# Altium schematic sheet [04] - INTERFACE BOARD MOMIU SCHEMATIC.SchDoc(Designator)
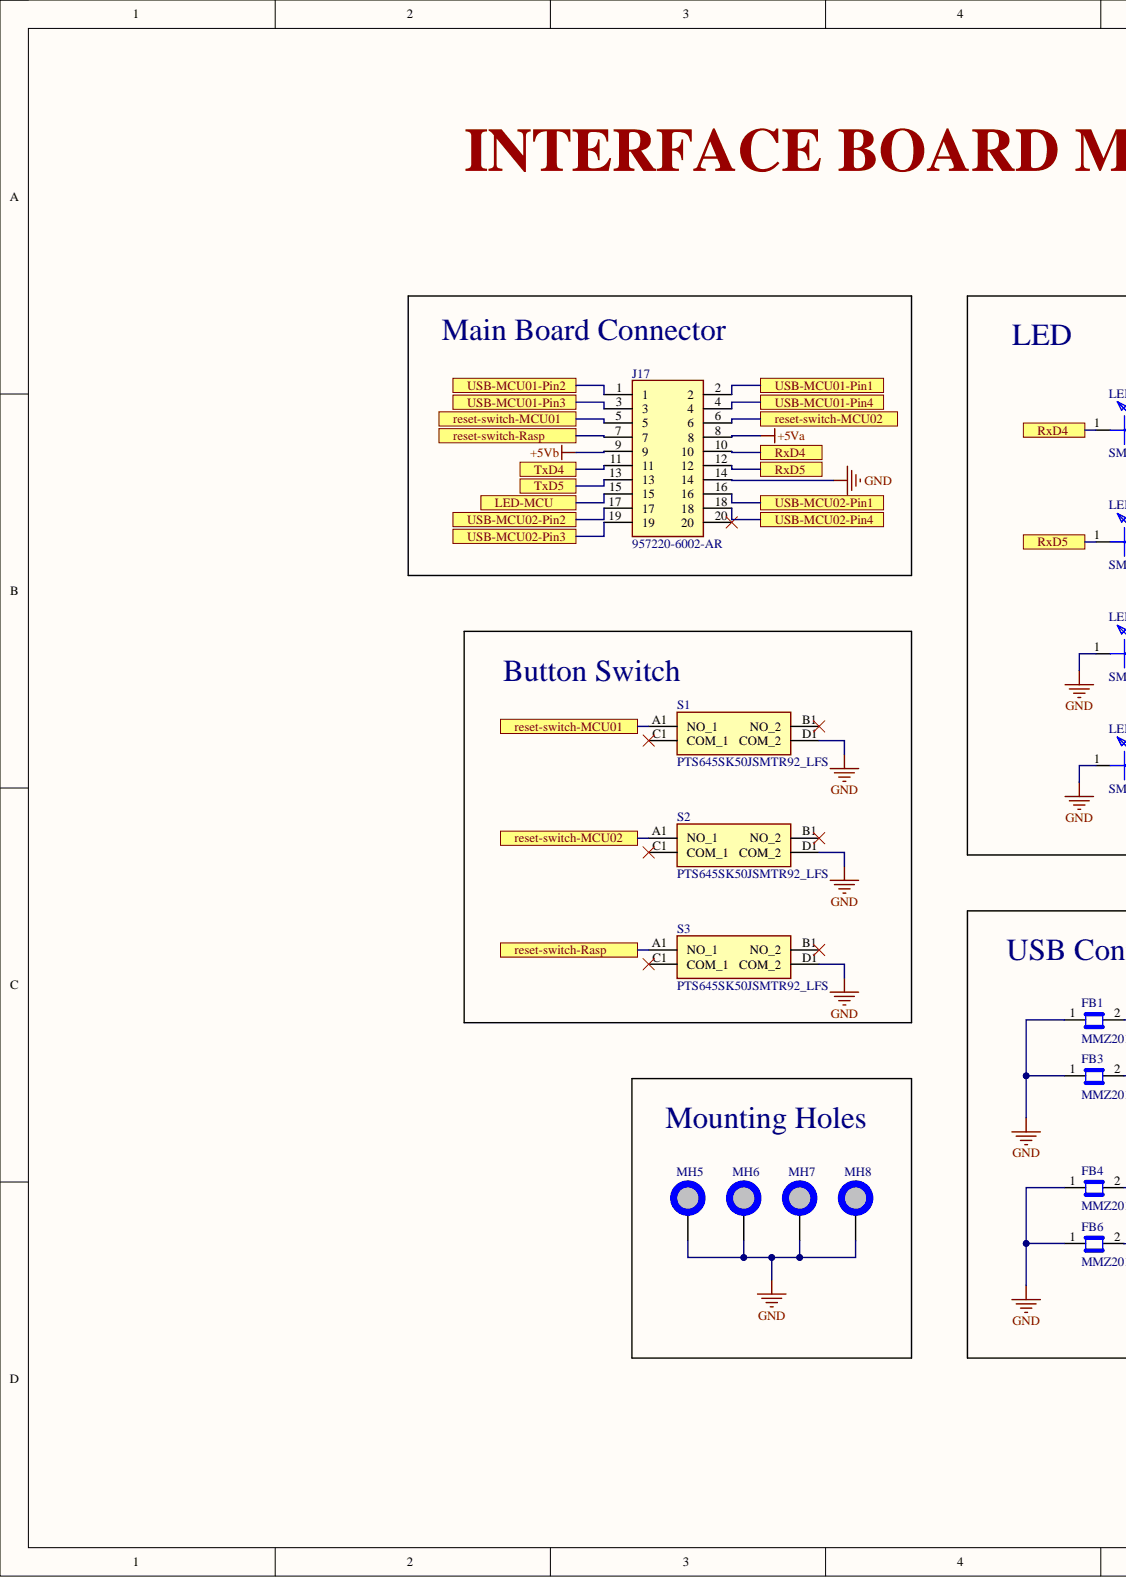
[diagram p0: [04] - INTERFACE BOARD MOMIU SCHEMATIC.SchDoc, left]
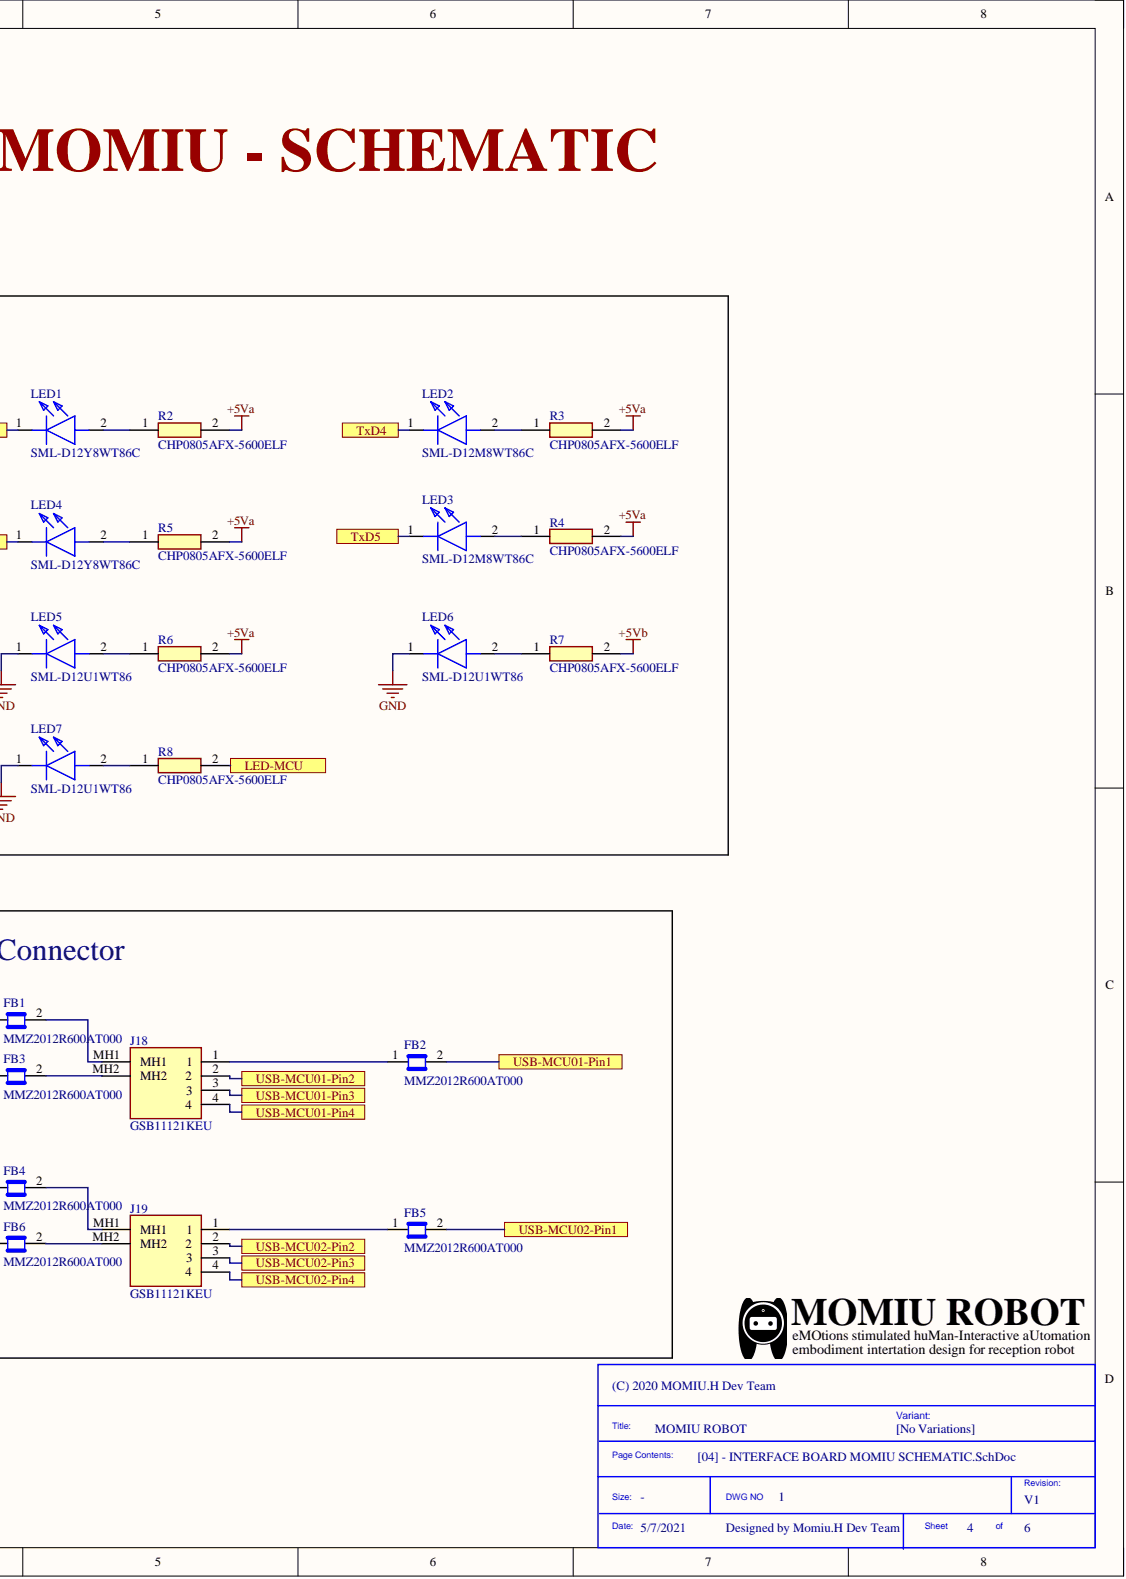
[diagram p1: [04] - INTERFACE BOARD MOMIU SCHEMATIC.SchDoc, right]

## Parts
FB1  MMZ2012R600AT000  [CAPC2012X100N]
FB2  MMZ2012R600AT000  [CAPC2012X100N]
FB3  MMZ2012R600AT000  [CAPC2012X100N]
FB4  MMZ2012R600AT000  [CAPC2012X100N]
FB5  MMZ2012R600AT000  [CAPC2012X100N]
FB6  MMZ2012R600AT000  [CAPC2012X100N]
J17  957220-6002-AR  [9572206002AR]
J18  GSB11121KEU  [GSB11121KEU]
J19  GSB11121KEU  [GSB11121KEU]
LED1  SML-D12Y8WT86C  [LEDC1608X65N]
LED2  SML-D12M8WT86C  [LEDC1608X65N]
LED3  SML-D12M8WT86C  [LEDC1608X65N]
LED4  SML-D12Y8WT86C  [LEDC1608X65N]
LED5  SML-D12U1WT86  [LEDC1608X65N]
LED6  SML-D12U1WT86  [LEDC1608X65N]
LED7  SML-D12U1WT86  [LEDC1608X65N]
MH5  MH_7.4 MMC_3.2 MMD  [MH_7.4 MMC_3.2 MMD]  (value not drawn)  (no BOM)
MH6  MH_7.4 MMC_3.2 MMD  [MH_7.4 MMC_3.2 MMD]  (value not drawn)  (no BOM)
MH7  MH_7.4 MMC_3.2 MMD  [MH_7.4 MMC_3.2 MMD]  (value not drawn)  (no BOM)
MH8  MH_7.4 MMC_3.2 MMD  [MH_7.4 MMC_3.2 MMD]  (value not drawn)  (no BOM)
R2  CHP0805AFX-5600ELF  [RESC2012X60N]
R3  CHP0805AFX-5600ELF  [RESC2012X60N]
R4  CHP0805AFX-5600ELF  [RESC2012X60N]
R5  CHP0805AFX-5600ELF  [RESC2012X60N]
R6  CHP0805AFX-5600ELF  [RESC2012X60N]
R7  CHP0805AFX-5600ELF  [RESC2012X60N]
R8  CHP0805AFX-5600ELF  [RESC2012X60N]
S1  PTS645SK50JSMTR92_LFS  [PTS645SK50JSMTR92LFS]
S2  PTS645SK50JSMTR92_LFS  [PTS645SK50JSMTR92LFS]
S3  PTS645SK50JSMTR92_LFS  [PTS645SK50JSMTR92LFS]

## Ports
LED-MCU, reset-switch-MCU01, reset-switch-MCU02, reset-switch-Rasp, RxD4, RxD5, TxD4, TxD5, USB-MCU01-Pin1, USB-MCU01-Pin2, USB-MCU01-Pin3, USB-MCU01-Pin4, USB-MCU02-Pin1, USB-MCU02-Pin2, USB-MCU02-Pin3, USB-MCU02-Pin4

## Nets
+5Va: J17.8 R2.2 R3.2 R4.2 R5.2 R6.2
+5Vb: J17.9 R7.2
GND: FB1.1 FB3.1 FB4.1 FB6.1 J17.14 LED5.1 LED6.1 LED7.1 MH5.1 MH6.1 MH7.1 MH8.1 S1.D1(COM_2) S2.D1(COM_2) S3.D1(COM_2)
LED-MCU: J17.15 R8.2 ; port LED-MCU
reset-switch-MCU01: J17.5 S1.A1(NO_1) ; port reset-switch-MCU01
reset-switch-MCU02: J17.6 S2.A1(NO_1) ; port reset-switch-MCU02
reset-switch-Rasp: J17.7 S3.A1(NO_1) ; port reset-switch-Rasp
RxD4: J17.10 LED1.1 ; port RxD4
RxD5: J17.12 LED4.1 ; port RxD5
TxD4: J17.11 LED2.1 ; port TxD4
TxD5: J17.13 LED3.1 ; port TxD5
USB-MCU01-Pin1: FB2.2 J17.2 ; port USB-MCU01-Pin1
USB-MCU01-Pin2: J17.1 J18.2 ; port USB-MCU01-Pin2
USB-MCU01-Pin3: J17.3 J18.3 ; port USB-MCU01-Pin3
USB-MCU01-Pin4: J17.4 J18.4 ; port USB-MCU01-Pin4
USB-MCU02-Pin1: FB5.2 J17.16 ; port USB-MCU02-Pin1
USB-MCU02-Pin2: J17.17 J19.2 ; port USB-MCU02-Pin2
USB-MCU02-Pin3: J17.19 J19.3 ; port USB-MCU02-Pin3
USB-MCU02-Pin4: J17.18 J19.4 ; port USB-MCU02-Pin4
NetFB1_2: FB1.2 J18.MH1
NetFB2_1: FB2.1 J18.1
NetFB3_2: FB3.2 J18.MH2
NetFB4_2: FB4.2 J19.MH1
NetFB5_1: FB5.1 J19.1
NetFB6_2: FB6.2 J19.MH2
NetLED1_2: LED1.2 R2.1
NetLED2_2: LED2.2 R3.1
NetLED3_2: LED3.2 R4.1
NetLED4_2: LED4.2 R5.1
NetLED5_2: LED5.2 R6.1
NetLED6_2: LED6.2 R7.1
NetLED7_2: LED7.2 R8.1

Not connected: J17 20; S1 B1(NO_2) C1(COM_1); S2 B1(NO_2) C1(COM_1); S3 B1(NO_2) C1(COM_1)

## Library data of the parts
FB1-FB6 = MMZ2012R600AT000: Ferrite Beads 60 OHM 25% @100 MHz; Component Kind: Standard; Library Name: SamacSys.SchLib; Supplier 1: Mouser; Supplier Part Number 1: 810-MMZ2012R600AT000
J17 = 957220-6002-AR: Connector; Manufacturer_Name: 3M; Arrow Part Number: 957220-6002-AR; Arrow Price/Stock: https://www.arrow.com/en/products/957220-6002-ar/3m; Component Kind: Standard; Datasheet Link: http://multimedia.3m.com/mws/media/1400507O/3m-box-header-957-series-78-5100-2656-6.pdf; Height: 5.8mm; Library Name: SamacSys.SchLib; Manufacturer_Part_Number: 957220-6002-AR; Mouser Part Number: 517-957220-6002-AR; Mouser Price/Stock: https://www.mouser.co.uk/ProductDetail/3M-Electronic-Solutions-Division/957220-6002-AR?qs=g1avbHDutKUCo0RviEjKSg%3D%3D; Supplier 1: Mouser; Supplier Part Number 1: 517-957220-6002-AR
J18 J19 = GSB11121KEU: Connector; Manufacturer_Name: Amphenol; Component Kind: Standard; Datasheet Link: https://cdn.amphenol-icc.com/media/wysiwyg/files/drawing/gsb111xxkeu.pdf; Height: 14.9mm; Library Name: SamacSys.SchLib; Manufacturer_Part_Number: GSB11121KEU; Supplier 1: Mouser; Supplier Part Number 1: 523-GSB11121KEU
LED1 LED4 = SML-D12Y8WT86C: LED; Manufacturer_Name: ROHM Semiconductor; Component Kind: Standard; Datasheet Link: https://www.rohm.com/datasheet/SML-D12Y8W(C)/sml-d12x8(c)-e; Height: 0.65mm; Library Name: SamacSys.SchLib; Manufacturer_Part_Number: SML-D12Y8WT86C; Mouser Part Number: 755-SML-D12Y8WT86C; Mouser Price/Stock: https://www.mouser.co.uk/ProductDetail/ROHM-Semiconductor/SML-D12Y8WT86C?qs=HXFqYaX1Q2zmWm4VzJ%252BtnA%3D%3D; Supplier 1: Mouser; Supplier Part Number 1: 755-SML-D12Y8WT86
LED2 LED3 = SML-D12M8WT86C: LED; Manufacturer_Name: ROHM Semiconductor; Component Kind: Standard; Datasheet Link: http://www.rohm.com/web/global/datasheet/SML-D12V8W; Height: 0.65mm; Library Name: SamacSys.SchLib; Manufacturer_Part_Number: SML-D12M8WT86C; Mouser Part Number: 755-SML-D12M8WT86C; Mouser Price/Stock: https://www.mouser.co.uk/ProductDetail/ROHM-Semiconductor/SML-D12M8WT86C?qs=HXFqYaX1Q2wmk6UmYQ4EIQ%3D%3D; Supplier 1: Mouser; Supplier Part Number 1: 755-SML-D12M8WT86
LED5-LED7 = SML-D12U1WT86: LED; Manufacturer_Name: ROHM Semiconductor; Component Kind: Standard; Datasheet Link: https://componentsearchengine.com/Datasheets/1/SML-D12U1WT86.pdf; Height: 0.65mm; Library Name: SamacSys.SchLib; Manufacturer_Part_Number: SML-D12U1WT86; Mouser Part Number: 755-SML-D12U1WT86; Mouser Price/Stock: https://www.mouser.co.uk/ProductDetail/ROHM-Semiconductor/SML-D12U1WT86?qs=M3jcYzEJUdEySuGkbjHEtQ%3D%3D; Supplier 1: Mouser; Supplier Part Number 1: 755-SML-D12U1WT86
MH5-MH8 = MH_7.4MMC_3.2MMD: Mounting Hole 7.4mm Diameter, 3.2mm Drill; Library Name: MyLib.SchLib
R2-R8 = CHP0805AFX-5600ELF: Resistor; Manufacturer_Name: Bourns; Component Kind: Standard; Datasheet Link: https://www.mouser.com/datasheet/2/54/chp-a-1858677.pdf; Height: 0.6mm; Library Name: SamacSys.SchLib; Manufacturer_Part_Number: CHP0805AFX-5600ELF; Mouser Part Number: 652-CHP0805AFX-5600L; Mouser Price/Stock: https://www.mouser.co.uk/ProductDetail/Bourns/CHP0805AFX-5600ELF/?qs=GedFDFLaBXHiLkJv9wkGiw%3D%3D; Supplier 1: Mouser; Supplier 2: Digi-Key; Supplier Part Number 1: 652-CHP0805AFX-5600L; Supplier Part Number 2: 118-CHP0805AFX-5600ELFCT-ND
S1-S3 = PTS645SK50JSMTR92_LFS: Switch; Manufacturer_Name: C & K COMPONENTS; Manufacturer_Part_Number: PTS645SK50JSMTR92 LFS; Component Kind: Standard; Datasheet Link: https://www.digikey.in/product-detail/en/c-k/PTS645SK50JSMTR92-LFS/108-PTS645SK50JSMTR92LFSCT-ND/12163485; Height: 5mm; Library Name: SamacSys.SchLib; Supplier 1: Mouser; Supplier Part Number 1: 611-SK50JSMTR92LFS
